# Altium schematic sheet DRV8353FS.SchDoc
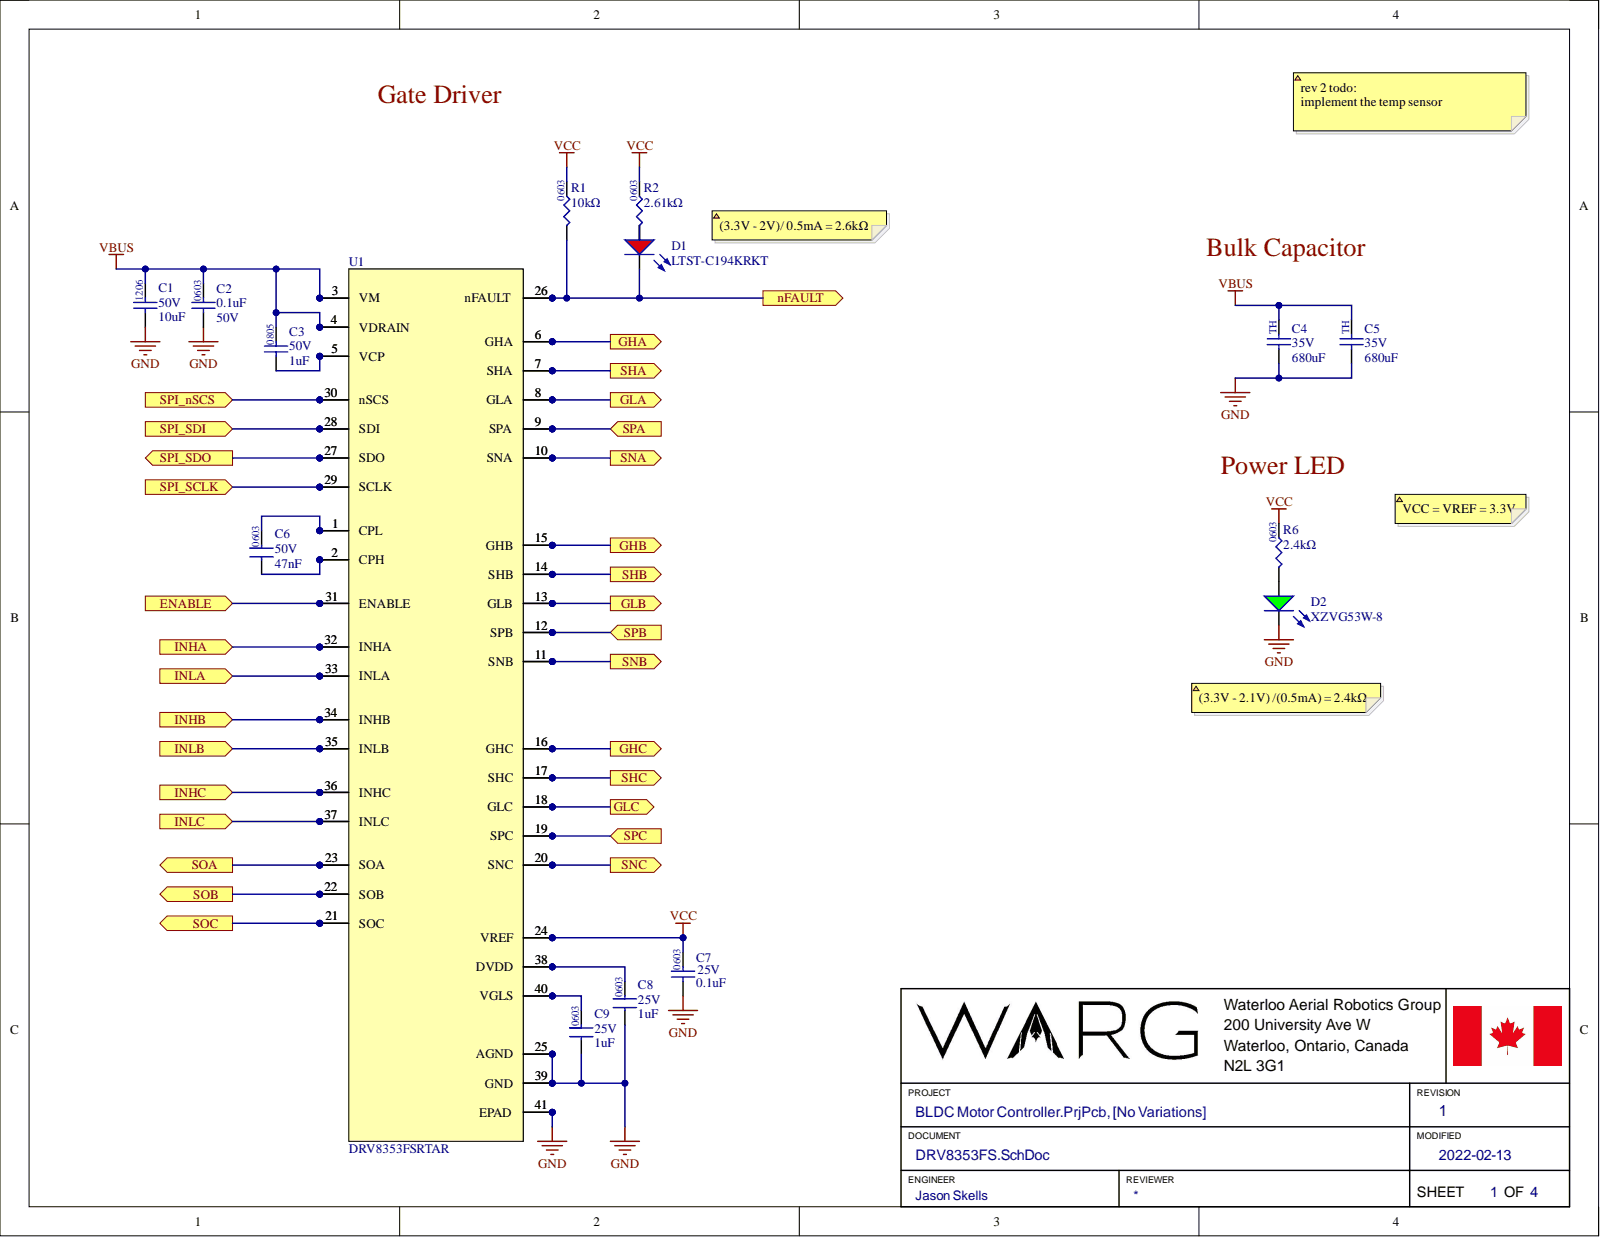
[diagram p0: DRV8353FS.SchDoc]

## Parts
C1  value 10uF  Package: 1206  Voltage: 50V  [CAP1206]  (hidden comment: CAP_10uF_50V_1206)
C2  value 0.1uF  Package: 0603  Voltage: 50V  [CAP0603]  (hidden comment: CAP_0.1uF_50V_0603)
C3  value 1uF  Package: 0805  Voltage: 50V  [CAP0805]  (hidden comment: CAP_1uF_50V_0805)
C4  Capacitance: 680uF  Voltage Rating: 35V  [CAP_TH_RADIAL]  (hidden comment: CAP_680uF_35V_TH_RUBYCON)
C5  Capacitance: 680uF  Voltage Rating: 35V  [CAP_TH_RADIAL]  (hidden comment: CAP_680uF_35V_TH_RUBYCON)
C6  Capacitance: 47nF  Case Code (Imperial): 0603  Voltage: 50V  [CAP0603]  (hidden comment: CAP_47nF_50V_0603)
C7  Capacitance: 0.1uF  Case Code (Imperial): 0603  Voltage: 25V  [CAP0603]  (hidden comment: CAP_0.1uF_25V_0603)
C8  Capacitance: 1uF  Case Code (Imperial): 0603  Voltage: 25V  [CAP0603]  (hidden comment: CAP_1uF_25V_0603)
C9  Capacitance: 1uF  Case Code (Imperial): 0603  Voltage: 25V  [CAP0603]  (hidden comment: CAP_1uF_25V_0603)
D1  Manufacturer Part Number 1: LTST-C194KRKT  [LED0603]  (hidden comment: LED_RED_2V_0603)
D2  Manufacturer Part Number 1: XZVG53W-8  [LED0603]  (hidden comment: LED_GREEN_2.1V_0603)
R1  value 10kΩ  Package: 0603  [RES0603]  (hidden comment: RES_10kΩ_0603_1%)
R2  Case Code (Imperial): 0603  Resistance: 2.61kΩ  [RES0603]  (hidden comment: RES_2.61kΩ_0603_1%)
R6  value 2.4kΩ  Package: 0603  [RES0603]  (hidden comment: RES_2.4kΩ_0603_1%)
U1  DRV8353FSRTAR  [DRV8353FS]

## Ports
ENABLE, GHA, GHB, GHC, GLA, GLB, GLC, INHA, INHB, INHC, INLA, INLB, INLC, nFAULT, SHA, SHB, SHC, SNA, SNB, SNC, SOA, SOB, SOC, SPA, SPB, SPC, SPI_nSCS, SPI_SCLK, SPI_SDI, SPI_SDO

## Nets
GND: C1.1 C2.1 C4.2 C5.2 C7.1 C8.1 C9.1 D2.2 U1.25(AGND) U1.39(GND) U1.41(EPAD)
VBUS: C1.2 C2.2 C3.2 C4.1 C5.1 U1.3(VM) U1.4(VDRAIN)
VCC: C7.2 R1.2 R2.2 R6.2 U1.24(VREF)
ENABLE: U1.31(ENABLE) ; port ENABLE
GHA: U1.6(GHA) ; port GHA
GHB: U1.15(GHB) ; port GHB
GHC: U1.16(GHC) ; port GHC
GLA: U1.8(GLA) ; port GLA
GLB: U1.13(GLB) ; port GLB
GLC: U1.18(GLC) ; port GLC
INHA: U1.32(INHA) ; port INHA
INHB: U1.34(INHB) ; port INHB
INHC: U1.36(INHC) ; port INHC
INLA: U1.33(INLA) ; port INLA
INLB: U1.35(INLB) ; port INLB
INLC: U1.37(INLC) ; port INLC
nFAULT: D1.2 R1.1 U1.26(nFAULT) ; port nFAULT
SHA: U1.7(SHA) ; port SHA
SHB: U1.14(SHB) ; port SHB
SHC: U1.17(SHC) ; port SHC
SNA: U1.10(SNA) ; port SNA
SNB: U1.11(SNB) ; port SNB
SNC: U1.20(SNC) ; port SNC
SOA: U1.23(SOA) ; port SOA
SOB: U1.22(SOB) ; port SOB
SOC: U1.21(SOC) ; port SOC
SPA: U1.9(SPA) ; port SPA
SPB: U1.12(SPB) ; port SPB
SPC: U1.19(SPC) ; port SPC
SPI_nSCS: U1.30(nSCS) ; port SPI_nSCS
SPI_SCLK: U1.29(SCLK) ; port SPI_SCLK
SPI_SDI: U1.28(SDI) ; port SPI_SDI
SPI_SDO: U1.27(SDO) ; port SPI_SDO
NetC3_1: C3.1 U1.5(VCP)
NetC6_1: C6.1 U1.2(CPH)
NetC6_2: C6.2 U1.1(CPL)
NetC8_2: C8.2 U1.38(DVDD)
NetC9_2: C9.2 U1.40(VGLS)
NetD1_1: D1.1 R2.1
NetD2_1: D2.1 R6.1

## Library data of the parts
C1 = CAP_10uF_50V_1206_MURATA: CAP CER 10UF 50V X5R ±20% 1206; Manufacturer 1: Murata Electronics; Manufacturer Part Number 1: GRT31CR61H106ME01L; Component Kind: Standard; Mounting Type: Surface Mount; Supplier 1: Digi-Key; Supplier Part Number 1: 490-12457-1-ND; Temperature Coefficient: X5R; Tolerance: ±20%; Library Name: WARG.SchLib
C2 = CAP_0.1uF_50V_0603_KEMET: CAP CER 0.1UF 50V X7R ±10% 0603; Manufacturer 1: KEMET; Manufacturer Part Number 1: C0603C104K5RACAUTO; Component Kind: Standard; LCSC Part #: C129621; Mounting Type: Surface Mount; Supplier 1: LCSC; Supplier Part Number 1: C129621; Temperature Coefficient: X7R; Tolerance: ±10%; Library Name: WARG.SchLib
C3 = CAP_1uF_50V_0805_MURATA: CAP CER 1UF 50V X7R ±10% 0805; Manufacturer 1: Murata Electronics; Manufacturer Part Number 1: GCJ21BR71H105KA01L; Component Kind: Standard; Mounting Type: Surface Mount; Supplier 1: Digi-Key; Supplier Part Number 1: 490-10667-1-ND; Temperature Coefficient: X7R; Tolerance: ±10%; Library Name: WARG.SchLib
C4 C5 = CAP_680uF_35V_TH_RUBYCON: Aluminum Electrolytic Capacitor, Polarized, Aluminum 35V, 20% +Tol, 20% -Tol, 680uF, Through Hole Mount; Manufacturer Part Number: 35ZLG680MEFC12.5X20; Impedance: 13mR; Life (Hours): 5000Hour; Ripple Current: 2.48A; Case/Package: Radial; Category: Aluminum Electrolytic Capacitors; Component Kind: Standard; ComponentLink1Description: http://www.rubycon.co.jp/en/catalog/e_pdfs/aluminum/e_zlg.pdf?src-supplier=Digi-Key; ComponentLink1URL: http://www.rubycon.co.jp/en/catalog/e_pdfs/aluminum/e_zlg.pdf?src-supplier=Digi-Key; Diameter: 12.5mm; Height: 20mm; Height - Seated (Max): 0.866inch; Lead Pitch: 0.197inch; Library Name: motor_controller_schem_lib.SchLib; Manufacturer: Rubycon; Max Operating Temperature: 105°C; Min Operating Temperature: -40°C; Mount: Through Hole; Package Quantity: 1000; Packaging: Bulk; Pricing 1: 1=1.10000, 10=0.86900, 50=0.75280, 100=0.65150, 500=0.49226, 1000=0.43436, 2500=0.40540, 5000=0.39092 (USD); RoHS: Yes; RoHS Compliant: Yes; Series: ZLG; Stock 1: 1633; Supplier 1: Digi-Key; Supplier Part Number 1: 1189-3696-ND; Tolerance: 20%; Voltage Rating (DC): 35V
C6 = CAP_47nF_50V_0603: CAP CER 47nF 50V X7R ±10% 0603; Manufacturer: KEMET; Manufacturer Part Number: C0603C473K5RACTU; Dielectric Material: Ceramic; Dissipation Factor: 2.5%; Case Code (Metric): 1608; Case/Package: 0603; Category: Ceramic Capacitors; Component Kind: Standard; ComponentLink1Description: https://datasheet.ciiva.com/6074/1776093-6074329.pdf?src-supplier=Farnell; ComponentLink1URL: https://datasheet.ciiva.com/6074/1776093-6074329.pdf?src-supplier=Farnell; ComponentLink2Description: https://datasheet.ciiva.com/28682/1851736-28682298.pdf?src-supplier=Element14; ComponentLink2URL: https://datasheet.ciiva.com/28682/1851736-28682298.pdf?src-supplier=Element14; ComponentLink3Description: https://datasheet.ciiva.com/18193/getdatasheetpartid-94279-18193115.pdf?src-supplier=Verical; ComponentLink3URL: https://datasheet.ciiva.com/18193/getdatasheetpartid-94279-18193115.pdf?src-supplier=Verical; ComponentLink4Description: https://datasheet.ciiva.com/7823/1776093-7823803.pdf?src-supplier=Element14; ComponentLink4URL: https://datasheet.ciiva.com/7823/1776093-7823803.pdf?src-supplier=Element14; ComponentLink5Description: https://datasheet.ciiva.com/7823/1776093-7823804.pdf?src-supplier=Element14; ComponentLink5URL: https://datasheet.ciiva.com/7823/1776093-7823804.pdf?src-supplier=Element14; Construction: Flat; Depth: 0.8mm; Dielectric: X7R; Height: 0.8mm; Lead Pitch: 0.7mm; Length: 1.6mm; Library Name: motor_controller_schem_lib.SchLib; Max Operating Temperature: 125°C; Min Operating Temperature: -55°C; Mount: Surface Mount,PCB; Number of Pins: 2; Package Quantity: 1; Packaging: Tape and Reel; Pricing 1: 1=0.10000, 10=0.07100, 50=0.03860, 100=0.03160, 500=0.02254, 1000=0.01771 (USD); Radiation Hardening: No; REACH SVHC: No SVHC; RoHS: Yes; RoHS Compliant: Yes; Series: C; Stock 1: 1778528; Supplier 1: Digi-Key; Supplier Part Number 1: 399-C0603C473K5RAC7867CT-ND; Termination: SMD/SMT; Thickness: 0.034inch; Tolerance: 10%; Voltage Rating: 50V; Voltage Rating (DC): 50V; Width: 0.8mm
C7 = CAP_0.1uF_25V_0603: Cap 100NF 25V 0603 SMD 10% X7R (reel); Manufacturer: Yageo; Manufacturer Part Number: CC0603KRX7R8BB104; Case Code (Metric): 1608; Case/Package: 0603; Category: Ceramic Capacitors; Component Kind: Standard; ComponentLink1Description: https://datasheet.ciiva.com/144/mlccorderingcode-144075.pdf?src-supplier=Digi-Key; ComponentLink1URL: https://datasheet.ciiva.com/144/mlccorderingcode-144075.pdf?src-supplier=Digi-Key; ComponentLink2Description: https://datasheet.ciiva.com/11786/0900766b80c10176-11786120.pdf?src-supplier=RSComponents; ComponentLink2URL: https://datasheet.ciiva.com/11786/0900766b80c10176-11786120.pdf?src-supplier=RSComponents; ComponentLink3Description: https://datasheet.ciiva.com/7978/1489800-7978155.pdf?src-supplier=Element14; ComponentLink3URL: https://datasheet.ciiva.com/7978/1489800-7978155.pdf?src-supplier=Element14; ComponentLink4Description: https://datasheet.ciiva.com/28450/1489800-28450584.pdf?src-supplier=Element14; ComponentLink4URL: https://datasheet.ciiva.com/28450/1489800-28450584.pdf?src-supplier=Element14; ComponentLink5Description: https://datasheet.ciiva.com/144/mlccorderingcode-144074.pdf?src-supplier=Digi-Key; ComponentLink5URL: https://datasheet.ciiva.com/144/mlccorderingcode-144074.pdf?src-supplier=Digi-Key; ComponentLink6Description: https://datasheet.ciiva.com/144/mlccorderingcode-144073.pdf?src-supplier=Digi-Key; ComponentLink6URL: https://datasheet.ciiva.com/144/mlccorderingcode-144073.pdf?src-supplier=Digi-Key; ComponentLink7Description: https://datasheet.ciiva.com/13389/upy-gphc-x7r-6-3v-to-50v-8-13389352.pdf?src-supplier=Mouser; ComponentLink7URL: https://datasheet.ciiva.com/13389/upy-gphc-x7r-6-3v-to-50v-8-13389352.pdf?src-supplier=Mouser; ComponentLink8Description: https://datasheet.ciiva.com/11587/upy-gphc-x7r-6-3v-to-50v-8-11587208.pdf?src-supplier=Avnet; ComponentLink8URL: https://datasheet.ciiva.com/11587/upy-gphc-x7r-6-3v-to-50v-8-11587208.pdf?src-supplier=Avnet; ComponentLink9Description: https://datasheet.ciiva.com/26829/getdatasheetpartid-403577-26829431.pdf?src-supplier=Verical; ComponentLink9URL: https://datasheet.ciiva.com/26829/getdatasheetpartid-403577-26829431.pdf?src-supplier=Verical; ComponentLink10Description: https://datasheet.ciiva.com/17434/upy-gphc-x7r-6-3v-to-50v-8-17434263.pdf?src-supplier=Avnet+Europe; ComponentLink10URL: https://datasheet.ciiva.com/17434/upy-gphc-x7r-6-3v-to-50v-8-17434263.pdf?src-supplier=Avnet+Europe; Depth: 0.8mm; Dielectric: X7R; Halogen Free: Halogen Free; Height: 0.8mm; Lead Free: Lead Free; Length: 1.6mm; Library Name: motor_controller_schem_lib.SchLib; Max Operating Temperature: 125°C; Min Operating Temperature: -55°C; Mount: Surface Mount; Number of Pins: 2; Package Quantity: 1; Packaging: Tape and Reel; Pricing 1: 1=0.10000, 10=0.04800, 50=0.02600, 100=0.02120, 500=0.01516, 1000=0.01192 (USD); Radiation Hardening: No; REACH SVHC: Unknown; Resistor Type: Ceramic; RoHS: Yes; RoHS Compliant: Yes; Series: CC; Stock 1: 4909660; Supplier 1: Digi-Key; Supplier Part Number 1: 311-1341-1-ND; Termination: SMD/SMT; Thickness: 0.035inch; Tolerance: 10%; Voltage Rating: 25V; Voltage Rating (DC): 25V; Width: 0.8mm
C8 C9 = CAP_1uF_25V_0603: CAP CER 1UF 25V X7R ±10% 0603; Manufacturer: Murata; Manufacturer Part Number: GCM188R71E105KA64D; Case Code (Metric): 1608; Case/Package: 0603; Category: Ceramic Capacitors; Component Kind: Standard; ComponentLink1Description: https://datasheet.ciiva.com/11616/product-11616499.pdf?src-supplier=Avnet; ComponentLink1URL: https://datasheet.ciiva.com/11616/product-11616499.pdf?src-supplier=Avnet; ComponentLink2Description: https://datasheet.ciiva.com/26843/getdatasheetpartid-562446-26843671.pdf?src-supplier=Verical; ComponentLink2URL: https://datasheet.ciiva.com/26843/getdatasheetpartid-562446-26843671.pdf?src-supplier=Verical; ComponentLink3Description: https://datasheet.ciiva.com/11786/0900766b80ef92d0-11786738.pdf?src-supplier=RSComponents; ComponentLink3URL: https://datasheet.ciiva.com/11786/0900766b80ef92d0-11786738.pdf?src-supplier=RSComponents; ComponentLink4Description: https://datasheet.ciiva.com/16624/product-16624556.pdf?src-supplier=Avnet+Europe; ComponentLink4URL: https://datasheet.ciiva.com/16624/product-16624556.pdf?src-supplier=Avnet+Europe; Construction: Flat; Depth: 0.8mm; Dielectric: X7R; Height: 0.8mm; Lead Free: Lead Free; Length: 1.6mm; Library Name: motor_controller_schem_lib.SchLib; Max Operating Temperature: 125°C; Min Operating Temperature: -55°C; Mount: Surface Mount; Package Quantity: 4000; Packaging: Tape and Reel; Pricing 1: 1=0.26000, 10=0.17800, 50=0.10680, 100=0.09010, 500=0.06166, 1000=0.05455 (USD); Radiation Hardening: No; Ratings: AEC-Q200; REACH SVHC: No SVHC; RoHS: Yes; RoHS Compliant: Yes; Series: GCM; Stock 1: 1715922; Supplier 1: Digi-Key; Supplier Part Number 1: 490-10673-1-ND; Termination: SMD/SMT; Thickness: 0.035inch; Tolerance: 10%; Voltage Rating: 25V; Voltage Rating (DC): 25V; Weight: 0.000222oz; Width: 0.031inch
D1 = LED_RED_2V_0603: LED RED 2V 0603; Manufacturer 1: Lite-On Inc.; Color: Red; Component Kind: Standard; Forward Voltage: 2V; Mounting Type: Surface Mount; Package: 0603; Supplier 1: Digi-Key; Supplier Part Number 1: 160-1835-1-ND; Library Name: WARG.SchLib
D2 = LED_GREEN_2.1V_0603: LED GREEN 2.1V 0603; Manufacturer 1: SunLED; Color: Green; Component Kind: Standard; Forward Voltage: 2.1V; Mounting Type: Surface Mount; Package: 0603; Supplier 1: Digi-Key; Supplier Part Number 1: 1497-1215-1-ND; Library Name: WARG.SchLib
R1 = RES_10kΩ_0603_1%: RES 10K OHM ±1% 1/10W 0603; Manufacturer 1: Yageo; Manufacturer Part Number 1: RC0603FR-0710KL; Component Kind: Standard; Mounting Type: Surface Mount; Power Rating: 1/10W; Supplier 1: Digi-Key; Supplier Part Number 1: 311-10.0KHRCT-ND; Tolerance: ±1%; Library Name: WARG.SchLib
R2 = RES_2.61kΩ_0603_1%: RES 2.61k OHM ±1% 1/10W 0603; Manufacturer: Yageo; Manufacturer Part Number: RC0603FR-072K61L; Max Power Dissipation: 100mW; Temperature Coefficient: 100ppm/°C; Voltage Rating: 75V; Voltage Rating (DC): 50V; Case Code (Metric): 1608; Case/Package: 0603; Category: Chip SMD Resistors; Component Kind: Standard; ComponentLink1Description: http://www.mouser.com/ds/2/447/RC0603-272842.pdf?src-supplier=Mouser; ComponentLink1URL: http://www.mouser.com/ds/2/447/RC0603-272842.pdf?src-supplier=Mouser; ComponentLink2Description: https://www.verical.com/server-webapp/html/getDatasheet?partID=329504&src-supplier=Verical; ComponentLink2URL: https://www.verical.com/server-webapp/html/getDatasheet?partID=329504&src-supplier=Verical; ComponentLink3Description: http://components.arrow.com/part/dataview/0323_03543055?src-supplier=Arrow+Europe; ComponentLink3URL: http://components.arrow.com/part/dataview/0323_03543055?src-supplier=Arrow+Europe; ComponentLink4Description: http://www.yageo.com/documents/recent/PYu-RC_Group_51_RoHS_L_9.pdf?src-supplier=Digi-Key; ComponentLink4URL: http://www.yageo.com/documents/recent/PYu-RC_Group_51_RoHS_L_9.pdf?src-supplier=Digi-Key; ComponentLink5Description: http://www.yageo.com/documents/recent/PYu-RC_Group_51_RoHS_L_10.pdf?src-supplier=Digi-Key; ComponentLink5URL: http://www.yageo.com/documents/recent/PYu-RC_Group_51_RoHS_L_10.pdf?src-supplier=Digi-Key; ComponentLink6Description: http://www.yageo.com/documents/recent/PYu-RC0603_51_RoHS_L_7.pdf?src-supplier=Future+Electronics; ComponentLink6URL: http://www.yageo.com/documents/recent/PYu-RC0603_51_RoHS_L_7.pdf?src-supplier=Future+Electronics; Construction: Rectangular; Depth: 0.8mm; Features: Moisture Resistant; Height: 0.45mm; Lead Free: Lead Free; Length: 1.6mm; Library Name: motor_controller_schem_lib.SchLib; Max Operating Temperature: 155°C; Military Standard: Not; Min Operating Temperature: -55°C; Number of Terminations: 2; Packaging: Tape and Reel; Power Rating: 100mW; Pricing 1: 1=0.10000, 10=0.02400, 100=0.00970, 1000=0.00436, 2500=0.00378 (USD); Resistor Type: Thick Film; RoHS: Yes; RoHS Compliant: Yes; Series: RC; Size Code: 0603; Stock 1: 103192; Supplier 1: Digi-Key; Supplier Part Number 1: 311-2.61KHRCT-ND; Termination: SMD/SMT; Tolerance: 1%; Weight: 7.1E-05oz; Width: 0.8mm
R6 = RES_2.4kΩ_0603_1%: RES 2.4K OHM ±1% 1/10W 0603; Manufacturer 1: Yageo; Manufacturer Part Number 1: RC0603FR-072K4L; Component Kind: Standard; Mounting Type: Surface Mount; Power Rating: 1/10W; Supplier 1: Digi-Key; Supplier Part Number 1: 311-2.40KHRCT-ND; Tolerance: ±1%; Library Name: WARG.SchLib
U1 = DRV8353FSRTAR: Multiphase Motor Driver Power MOSFET PWM, SPI 40-WQFN (6x6); Manufacturer: Texas Instruments; Component Kind: Standard; Manufacturer Part Number 1: DRV8353FSRTAR; Mounting Type: Surface Mount; Package: 40-WFQFN Exposed Pad; Supplier 1: Digi-Key; Supplier Part Number 1: 296-DRV8353FSRTARCT-ND; Library Name: WARG.SchLib
